# Altium schematic sheet SPI Extender 1.SchDoc
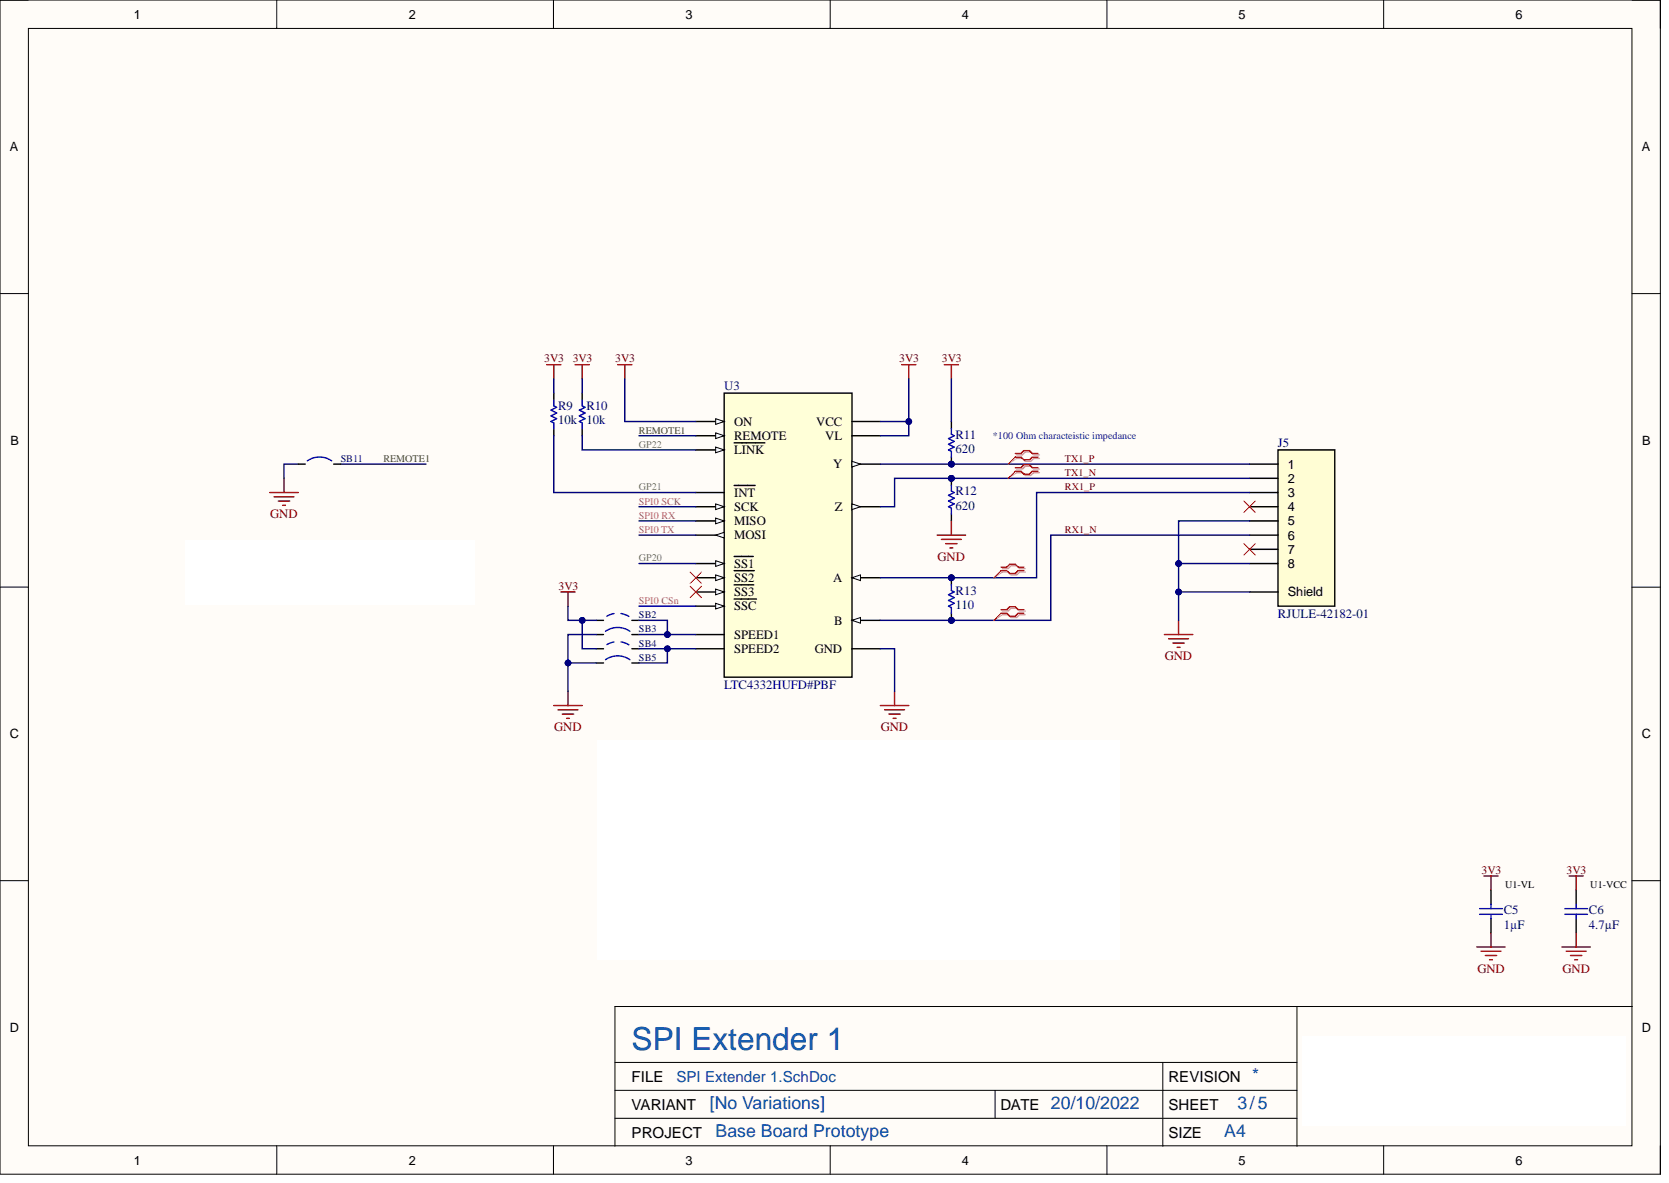
[diagram p0: SPI Extender 1.SchDoc]

## Parts
C5  value 1µF  [FP-GRM188-0_1-e0_2_0_5-IPC_B]  (hidden comment: C0603-1uF-25V-10%-X7R)
C6  value 4.7µF  [CAPC2012X88N]  (hidden comment: C0805-4.7uF-16V-5%-X7R)
J5  RJULE4218201  PartNumber: RJULE-42182-01  [RJULE-42182-01 - RM]
R9  value 10k  Resistance: 10k  [FP-CPF0603-IPC_B]  (hidden comment: R0603-10k-1/16W-0.1%)
R10  value 10k  Resistance: 10k  [FP-CPF0603-IPC_B]  (hidden comment: R0603-10k-1/16W-0.1%)
R11  value 620  [FP-CPF0603-IPC_B]  (hidden comment: R0603-620R-1/16W-0.1%)
R12  value 620  [FP-CPF0603-IPC_B]  (hidden comment: R0603-620R-1/16W-0.1%)
R13  value 110  [FP-CPF0603-IPC_B]  (hidden comment: R0603-110R-1/16W-0.1%)
SB2  Solder Bridge 0603 Off  [Solder Bridge 0603 Off]  (value not drawn)  (no BOM)
SB3  Solder Bridge 0603 On  [Solder Bridge 0603 On]  (value not drawn)
SB4  Solder Bridge 0603 Off  [Solder Bridge 0603 Off]  (value not drawn)  (no BOM)
SB5  Solder Bridge 0603 On  [Solder Bridge 0603 On]  (value not drawn)
SB11  Solder Bridge 0603 On  [Solder Bridge 0603 On]  (value not drawn)
U3  LTC4332HUFD#PBF  [FP-UFD-20-05-08-1711-IPC_B]

## Nets
3V3: C5.2 C6.2 R9.2 R10.2 R11.2 SB2.1 SB4.1 U3.1(VCC) U3.2(VL) U3.3(ON)
GND: C5.1 C6.1 J5.5 J5.8 J5.MH(Shield) R12.1 SB3.1 SB5.1 SB11.1 U3.16(GND)
GP20: U3.11(SS1)
GP21: R9.1 U3.10(INT)
GP22: R10.1 U3.5(LINK)
REMOTE1: SB11.2 U3.4(REMOTE)
RX1_N: J5.6 R13.1 U3.19(B)
RX1_P: J5.3 R13.2 U3.20(A)
SPI0 CSn: U3.6(SSC)
SPI0 RX: U3.9(MISO)
SPI0 SCK: U3.7(SCK)
SPI0 TX: U3.8(MOSI)
TX1_N: J5.2 R12.2 U3.18(Z)
TX1_P: J5.1 R11.1 U3.17(Y)
NetSB2_2: SB2.2 SB3.2 U3.14(SPEED1)
NetSB4_2: SB4.2 SB5.2 U3.15(SPEED2)

Not connected: J5 4 7; U3 12(SS2) 13(SS3)

## Library data of the parts
C5 = CMP-611-0033-2: 1µF ±10% 25V Ceramic Capacitor X7R 0603 (1608 Metric); Capacitance: 1uF; Pick&PlaceFootprint: 0603_CAP; Capacitor Type: Ceramic; Case Code (Imperial): 0603; Case Code (Metric): 1608; Case/Package: 0603; Component Kind: Standard; Construction: Flat; Depth: 0.8mm; Dielectric: X7R; Height: 0.9mm; Lead Free: Lead Free; Length: 1.6mm; Material: Ceramic; Max Operating Temperature: 125°C; Min Operating Temperature: -55°C; Mount: Surface Mount; Package Quantity: 1; Packaging: Tape and Reel; Radiation Hardening: No; REACH SVHC: Yes; RoHS: Yes; RoHS Compliant: Yes; Series: GRM; Size: 0.8mm; Termination: Wraparound; Thickness: 0.035inch; Tolerance: 10%; Voltage: 25V; Voltage Rating: 25V; Voltage Rating (DC): 25V; Weight: 0.000222oz; Width: 0.8mm; Library Name: Sainsbury Wellcome Centre
C6 = CMP-611-0019-6: 4.7uF ±5% 16V Ceramic Capacitor X7R 0805 (2012 Metric); Dissipation Factor: 0.025%; Pick&PlaceFootprint: C0805; Capacitance: 4.7uF; Capacitor Type: Ceramic; Case Code (Imperial): 0805; Case Code (Metric): 2012; Case/Package: 0805; Component Kind: Standard; Depth: 1.25mm; Dielectric: X7R; Height: 1.25mm; Lead Free: Lead Free; Length: 2mm; Material: Ceramic; Max Operating Temperature: 125°C; Min Operating Temperature: -55°C; Mount: Surface Mount; Number of Pins: 2; Package Quantity: 1; Packaging: Cut Tape; Radiation Hardening: No; REACH SVHC: No SVHC; RoHS: Yes; Termination: SMD/SMT; Thickness: 0.053inch; Tolerance: 5%; Voltage: 16V; Voltage Rating: 16V; Voltage Rating (DC): 16V; Width: 0.049inch; Library Name: Sainsbury Wellcome Centre
J5 = CMP-300-0001-5: Modular Jack - Right Angle, Input Output Connectors RJ45, 8P8C, Without LEDs, Shield.; Component Kind: Standard; Mount: Surface Mount; Library Name: Sainsbury Wellcome Centre
R9 R10 = CMP-633-0002-15: Surface Mount Resistor 10k Ohm 1/16 W 0.1% 0603 (1608 Metric); Max Power Dissipation: 62.5 mW; Number of Resistors: 1; Pick&PlaceFootprint: R0603; Power Rating: 62.5mW; Temperature Coefficient: 25ppm/°C; Voltage Rating: 50V; Case Code (Imperial): 0603; Case Code (Metric): 1608; Case/Package: 0603; Component Kind: Standard; Composition: Thin Film; ELV: Compliant; Height: 0.45mm; Length: 1.6mm; Max Operating Temperature: 155°C; Min Operating Temperature: -55°C; Mount: Surface Mount; Number of Pins: 2; Number of Terminations: 2; Packaging: Tape and Reel; REACH SVHC: No SVHC; Resistance Tolerance: 0.1%; Resistor Type: Thin Film; RoHS: Yes; RoHS Compliant: Yes; Series: CPF; Size Code: 0603; Technology: Thin Film; Termination: Solder; Tolerance: 0.1%; Voltage Rating (DC): 50V; Weight: 7.1E-05oz; Width: 0.8mm; Library Name: Sainsbury Wellcome Centre
R11 R12 = CMP-633-0079-2: Surface Mount Resistor 620 Ohm 1/16 W 0.1% 0603 (1608 Metric); Max Power Dissipation: 62.5 mW; Number of Resistors: 1; Pick&PlaceFootprint: R0603; Power Rating: 62.5mW; Temperature Coefficient: 25ppm/°C; Voltage Rating: 50V; Case Code (Imperial): 0603; Case Code (Metric): 1608; Case/Package: 0603; Component Kind: Standard; Composition: Thin Film; Construction: Chip; ELV: Compliant; Height: 0.45mm; Length: 1.6mm; Max Operating Temperature: 155°C; Min Operating Temperature: -55°C; Mount: Surface Mount; Number of Pins: 2; Number of Terminations: 2; Packaging: Tape and Reel; REACH SVHC: Yes; Resistance: 620; Resistance Tolerance: 0.1%; Resistor Type: FIXED RESISTOR; RoHS: Yes; RoHS Compliant: Yes; Series: CPF; Size Code: 0603; Technology: THIN FILM; Termination: Wraparound; Tolerance: 0.1%; Voltage Rating (DC): 50V; Weight: 7.1E-05oz; Width: 0.8mm; Library Name: Sainsbury Wellcome Centre
R13 = CMP-633-0080-2: Surface Mount Resistor 110 Ohm 1/16 W 0.1% 0603 (1608 Metric); Max Power Dissipation: 62.5 mW; Number of Resistors: 1; Pick&PlaceFootprint: R0603; Power Rating: 62.5mW; Temperature Coefficient: 25ppm/°C; Voltage Rating: 50V; Case Code (Imperial): 0603; Case Code (Metric): 1608; Case/Package: 0603; Component Kind: Standard; Composition: Thin Film; Construction: Chip; ELV: Compliant; Height: 0.45mm; Length: 1.6mm; Max Operating Temperature: 155°C; Min Operating Temperature: -55°C; Mount: Surface Mount; Number of Pins: 2; Number of Terminations: 2; Packaging: Tape and Reel; REACH SVHC: Yes; Resistance: 110; Resistance Tolerance: 0.1%; Resistor Type: FIXED RESISTOR; RoHS: Yes; RoHS Compliant: Yes; Series: CPF; Size Code: 0603; Technology: THIN FILM; Termination: Wraparound; Tolerance: 0.1%; Voltage Rating (DC): 50V; Weight: 7.1E-05oz; Width: 0.8mm; Library Name: Sainsbury Wellcome Centre
SB2 SB4 = CMP-B00-0001-2: Description: Solder Bridge 0603 OFF; Library Name: Sainsbury Wellcome Centre
SB3 SB5 SB11 = CMP-B00-0000-4: PartNumber: R0603-0R-1/16W-0.1%; Pick&PlaceFootprint: R0603; Case/Package: R0603; Component Kind: Standard; Description: Solder Bridge 0603 ON; Mount: SMD; Pins: 2; Library Name: Sainsbury Wellcome Centre
U3 = CMP-930-0001-1: SPI Extender Over Rugged Differential Link; Pick&PlaceFootprint: QFN-20-4-5; Component Kind: Standard; PartNumber: LTC4332HUFD#PBF; RoHS Compliant: Yes; Library Name: Sainsbury Wellcome Centre
